# Altium schematic sheet [14]-CURRENT_METER.SchDoc([14]-CURRENT_METER)
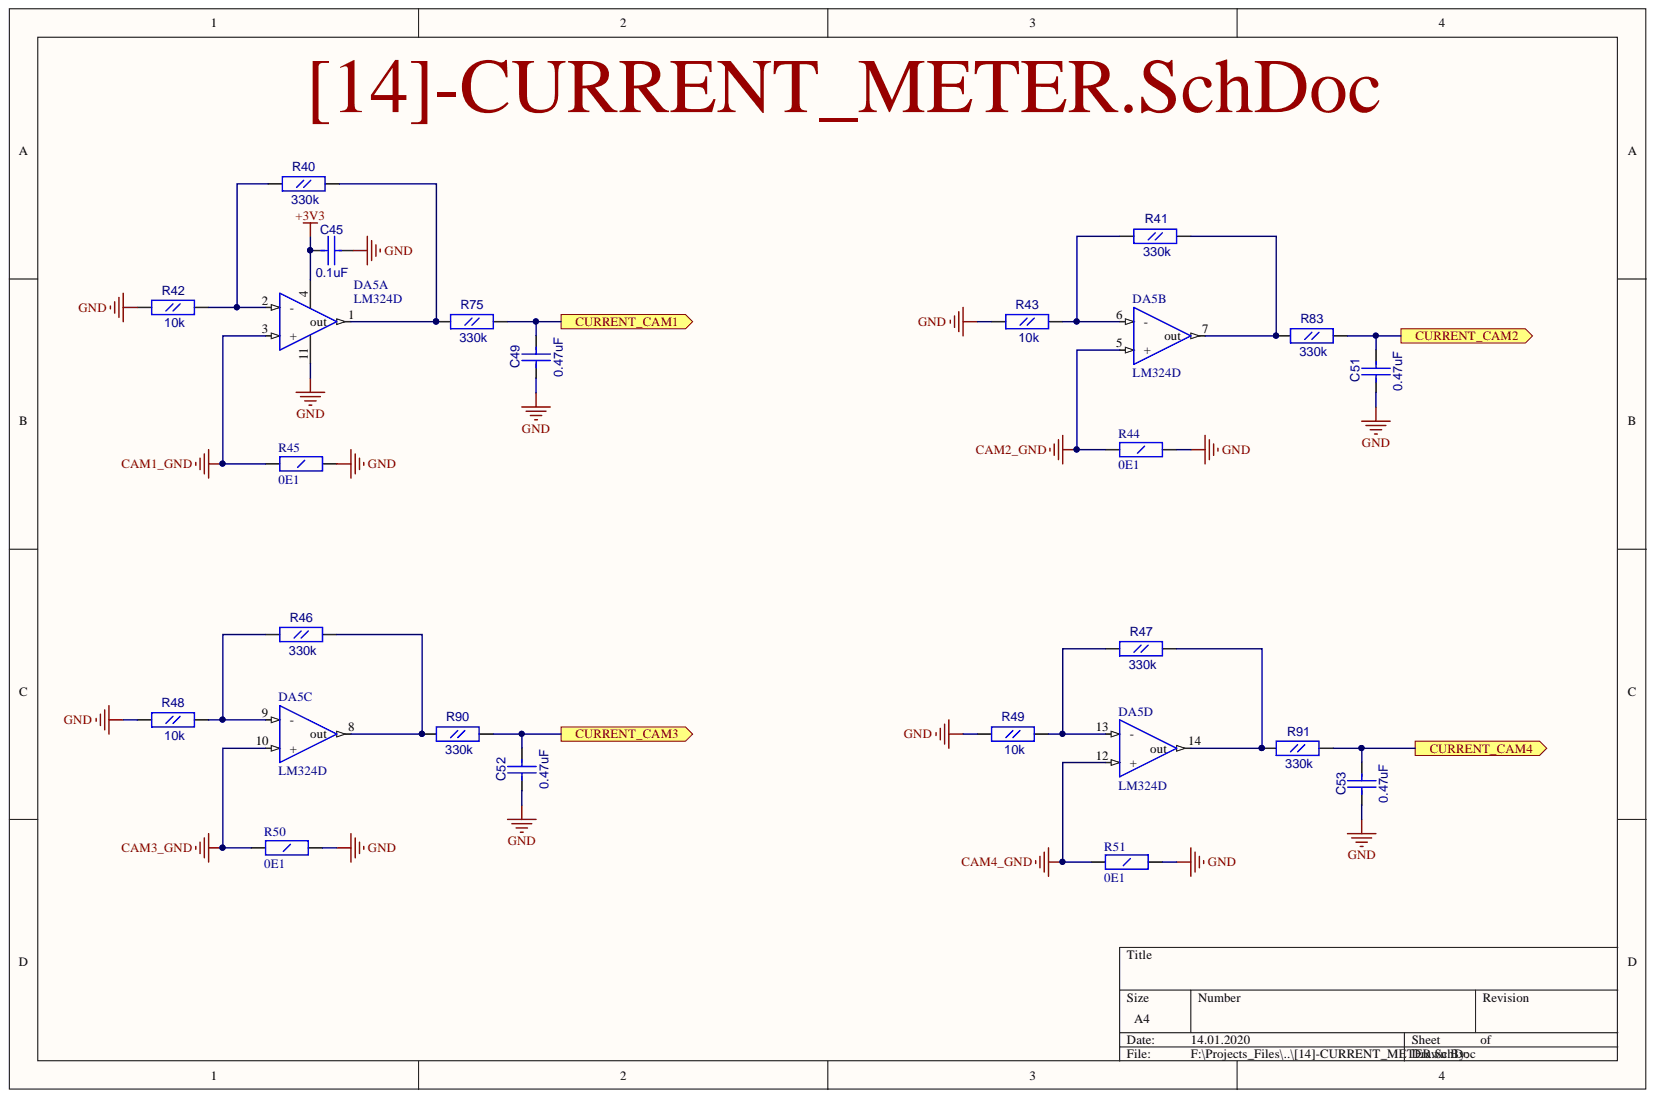
[diagram p0: [14]-CURRENT_METER.SchDoc]

## Parts
C45  0.1uF  [CC0603]
C49  0.47uF  [CC0603]
C51  0.47uF  [CC0603]
C52  0.47uF  [CC0603]
C53  0.47uF  [CC0603]
DA5  LM324D  [SO14]  (parts A,B,C,D)
R40  330k  [RC0603]
R41  330k  [RC0603]
R42  10k  [RC0603]
R43  10k  [RC0603]
R44  0E1  [R2512]
R45  0E1  [R2512]
R46  330k  [RC0603]
R47  330k  [RC0603]
R48  10k  [RC0603]
R49  10k  [RC0603]
R50  0E1  [R2512]
R51  0E1  [R2512]
R75  330k  [RC0603]
R83  330k  [RC0603]
R90  330k  [RC0603]
R91  330k  [RC0603]

## Ports
CURRENT_CAM1, CURRENT_CAM2, CURRENT_CAM3, CURRENT_CAM4

## Nets
+3V3: C45.1 DA5.4
CAM1_GND: DA5.3(+) R45.2
CAM2_GND: DA5.5(+) R44.2
CAM3_GND: DA5.10(+) R50.2
CAM4_GND: DA5.12(+) R51.2
GND: C45.2 C49.1 C51.1 C52.1 C53.1 DA5.11 R42.1 R43.1 R44.1 R45.1 R48.1 R49.1 R50.1 R51.1
CURRENT_CAM1: C49.2 R75.2 ; port CURRENT_CAM1
CURRENT_CAM2: C51.2 R83.2 ; port CURRENT_CAM2
CURRENT_CAM3: C52.2 R90.2 ; port CURRENT_CAM3
CURRENT_CAM4: C53.2 R91.2 ; port CURRENT_CAM4
NetDA5_1: DA5.1(out) R40.2 R75.1
NetDA5_2: DA5.2(-) R40.1 R42.2
NetDA5_6: DA5.6(-) R41.1 R43.2
NetDA5_7: DA5.7(out) R41.2 R83.1
NetDA5_8: DA5.8(out) R46.2 R90.1
NetDA5_9: DA5.9(-) R46.1 R48.2
NetDA5_13: DA5.13(-) R47.1 R49.2
NetDA5_14: DA5.14(out) R47.2 R91.1

## Library data of the parts
C45 C49 C51-C53 = CC0603: Description: CC0603; Component Kind: Standard; Library Name: fizik_lib.SchLib
  C45: Value: 0.1uF
  C49 C51-C53: Value: 0.47uF
R40-R43 R46-R49 R75 R83 R90 R91 = RC0603: Description: RC0603; Component Kind: Standard; Library Name: fizik_lib.SchLib
  R40 R41 R46 R47 R75 R83 R90 R91: Value: 330k
  R42 R43 R48 R49: Value: 10k
R44 R45 R50 R51 = RC2512: Resistor 2512 1W; Value: 0E1; Component Kind: Standard; Library Name: fizik_lib.SchLib
DA5 = LM324D: Value: LM324D; Component Kind: Standard; Library Name: fizik_lib.SchLib
